# Altium schematic sheet Külmkapivalvur.SchDoc
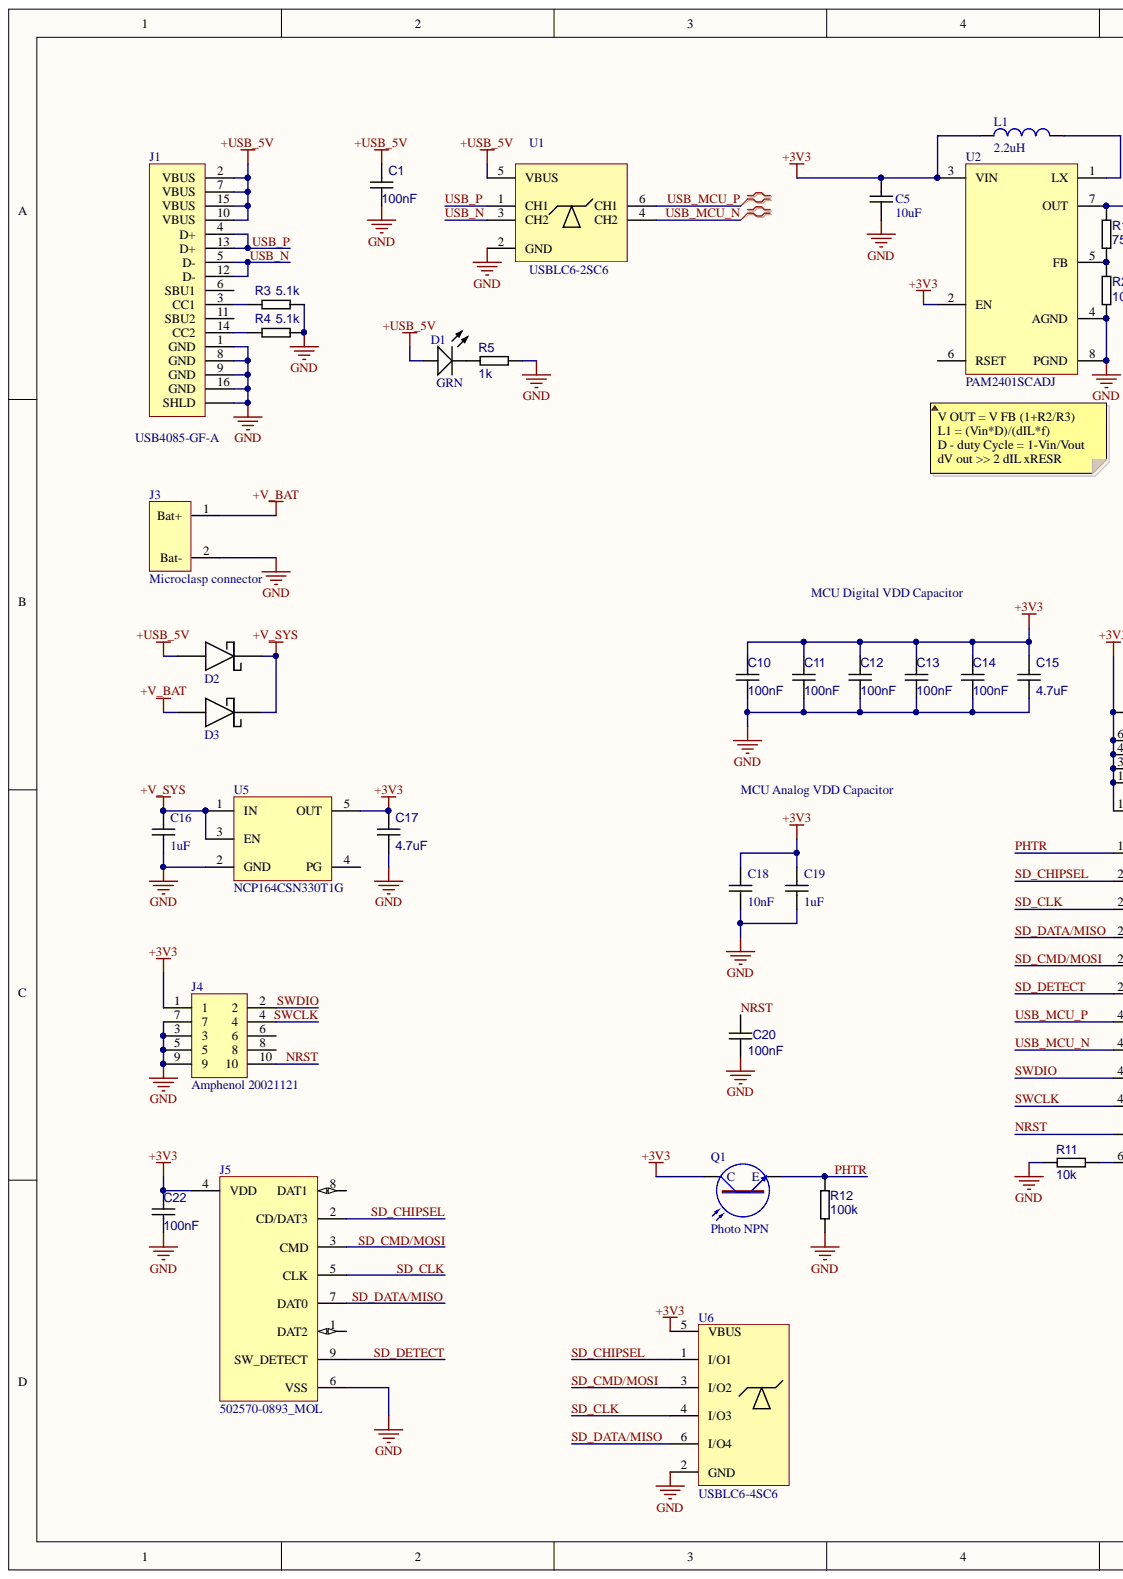
[diagram p0: Külmkapivalvur.SchDoc, left]
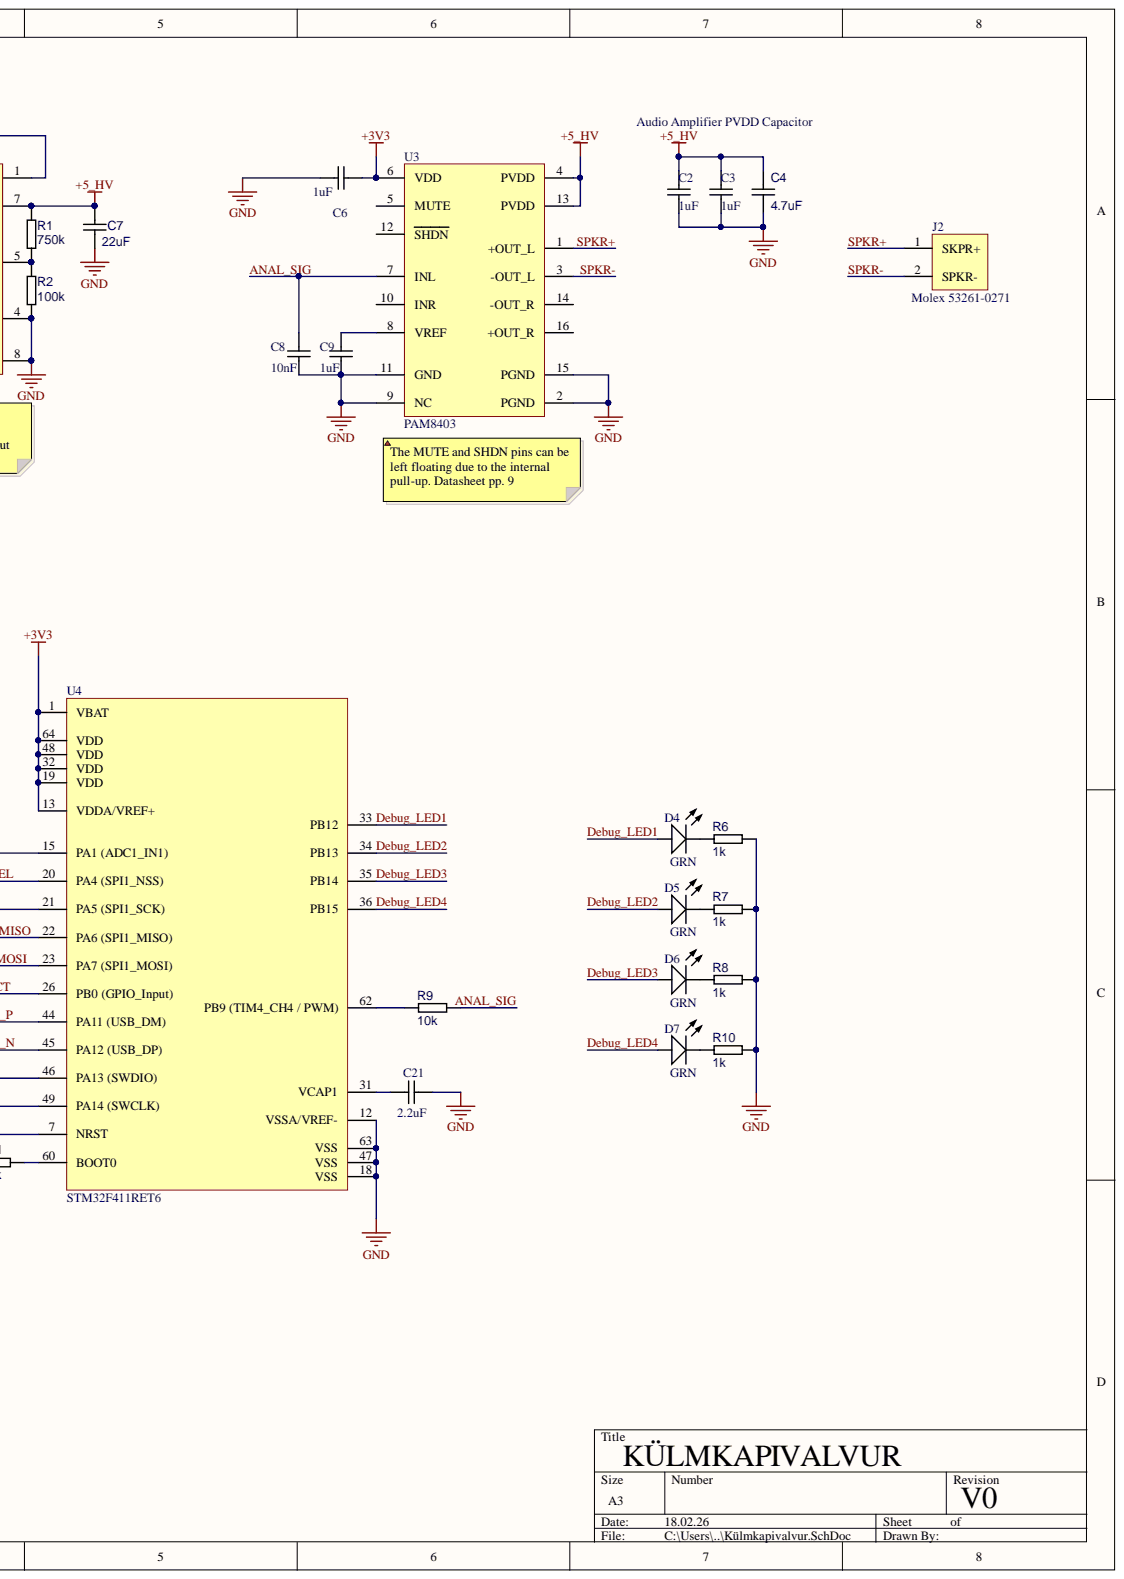
[diagram p1: Külmkapivalvur.SchDoc, right]

## Parts
C1  100nF  [0603C]
C2  1uF  [0603C]
C3  1uF  [0603C]
C4  4.7uF  [0603C]
C5  10uF  [0603C]
C6  1uF  [0603C]
C7  22uF  [0805C]
C8  10nF  [0603C]
C9  1uF  [0603C]
C10  100nF  [0603C]
C11  100nF  [0603C]
C12  100nF  [0603C]
C13  100nF  [0603C]
C14  100nF  [0603C]
C15  4.7uF  [0603C]
C16  1uF  [0603C]
C17  4.7uF  [0603C]
C18  10nF  [0603C]
C19  1uF  [0603C]
C20  100nF  [0603C]
C21  2.2uF  [0603C]
C22  100nF  [0603C]
D1  GRN  [LED_GRN]
D2  NRVBSS36FA  [NRVBSS36FA]  (value not drawn)
D3  NRVBSS36FA  [NRVBSS36FA]  (value not drawn)
D4  GRN  [LED_GRN]
D5  GRN  [LED_GRN]
D6  GRN  [LED_GRN]
D7  GRN  [LED_GRN]
J1  USB4085-GF-A  [CONN16_USB4085-GF-A_GCT]
J2  Molex 53261-0271  [Speaker connector]
J3  Microclasp connector  [CONN02_559350210_MOL]
J4  Amphenol 20021121  [AMPHENOL_20021121-00010C4LF]
J5  502570-0893_MOL  [502570-0893_MOL]
L1  value 2.2uH  [IND_NR4018_2.2uH]  (hidden comment: Inductor)
Q1  Photo NPN  [Phototransistor]
R1  750kR  value 750k  [0603R]
R2  100kR  value 100k  [0603R]
R3  value 5.1k  [0603R]  (hidden comment: 5.1kR)
R4  value 5.1k  [0603R]  (hidden comment: 5.1kR)
R5  value 1k  [0603R]  (hidden comment: 1kR)
R6  value 1k  [0603R]  (hidden comment: 1kR)
R7  value 1k  [0603R]  (hidden comment: 1kR)
R8  value 1k  [0603R]  (hidden comment: 1kR)
R9  10kR  value 10k  [0603R]
R10  value 1k  [0603R]  (hidden comment: 1kR)
R11  10kR  value 10k  [0603R]
R12  100kR  value 100k  [0603R]
U1  USBLC6-2SC6  [SOT23-6_ESD]
U2  PAM2401SCADJ  [MSOP-8_PAM2401]
U3  PAM8403  [SOIC16_PAM8403]
U4  STM32F411RET6  [STM32F411RET6]
U5  NCP164CSN330T1G  [SOT23-5_LDO]
U6  USBLC6-4SC6  [SOT23-6_ESD]

## Nets
+3V3: C5.2 C6.2 C10.2 C11.2 C12.2 C13.2 C14.2 C15.2 C17.2 C18.2 C19.2 C22.2 J4.1 J5.4(VDD) L1.1 Q1.1 U2.2(EN) U2.3(VIN) U3.6(VDD) U4.1(VBAT) U4.13(VDDA/VREF+) U4.19(VDD) U4.32(VDD) U4.48(VDD) U4.64(VDD) U5.5(OUT) U6.5(VBUS)
+5_HV: C2.2 C3.2 C4.2 C7.2 R1.2 U2.7(OUT) U3.4(PVDD) U3.13(PVDD)
+USB_5V: C1.2 D1.1 D2.2 J1.2(VBUS) J1.7(VBUS) J1.10(VBUS) J1.15(VBUS) U1.5(VBUS)
+V_BAT: D3.2 J3.1
+V_SYS: C16.2 D2.1 D3.1 U5.1(IN) U5.3(EN)
GND: C1.1 C2.1 C3.1 C4.1 C5.1 C6.1 C7.1 C8.1 C9.1 C10.1 C11.1 C12.1 C13.1 C14.1 C15.1 C16.1 C17.1 C18.1 C19.1 C20.1 C21.2 C22.1 J1.1(GND) J1.8(GND) J1.9(GND) J1.16(GND) J1.SHLD J3.2 J4.3 J4.5 J4.7 J4.9 J5.6(VSS) R2.1 R3.1 R4.1 R5.2 R6.2 R7.2 R8.2 R10.2 R11.1 R12.1 U1.2(GND) U2.4(AGND) U2.8(PGND) U3.2(PGND) U3.9(NC) U3.11(GND) U3.15(PGND) U4.12(VSSA/VREF-) U4.18(VSS) U4.47(VSS) U4.63(VSS) U5.2(GND) U6.2(GND)
ANAL_SIG: C8.2 R9.2 U3.7(INL)
Debug_LED1: D4.1 U4.33(PB12)
Debug_LED2: D5.1 U4.34(PB13)
Debug_LED3: D6.1 U4.35(PB14)
Debug_LED4: D7.1 U4.36(PB15)
NRST: C20.2 J4.10 U4.7(NRST)
PHTR: Q1.2 R12.2 U4.15(PA1_(ADC1_IN1))
SD_CHIPSEL: J5.2(CD/DAT3) U4.20(PA4_(SPI1_NSS)) U6.1(I/O1)
SD_CLK: J5.5(CLK) U4.21(PA5_(SPI1_SCK)) U6.4(I/O3)
SD_CMD/MOSI: J5.3(CMD) U4.23(PA7_(SPI1_MOSI)) U6.3(I/O2)
SD_DATA/MISO: J5.7(DAT0) U4.22(PA6_(SPI1_MISO)) U6.6(I/O4)
SD_DETECT: J5.9(SW_DETECT) U4.26(PB0_(GPIO_Input))
SPKR+: J2.1 U3.1(+OUT_L)
SPKR-: J2.2 U3.3(-OUT_L)
SWCLK: J4.4 U4.49(PA14_(SWCLK))
SWDIO: J4.2 U4.46(PA13_(SWDIO))
USB_MCU_N: U1.4(CH2) U4.45(PA12_(USB_DP))
USB_MCU_P: U1.6(CH1) U4.44(PA11_(USB_DM))
USB_N: J1.5(D-) J1.12(D-) U1.3(CH2)
USB_P: J1.4(D+) J1.13(D+) U1.1(CH1)
NetC9_2: C9.2 U3.8(VREF)
NetC21_1: C21.1 U4.31(VCAP1)
NetD1_2: D1.2 R5.1
NetD4_2: D4.2 R6.1
NetD5_2: D5.2 R7.1
NetD6_2: D6.2 R8.1
NetD7_2: D7.2 R10.1
NetJ1_3: J1.3(CC1) R3.2
NetJ1_14: J1.14(CC2) R4.2
NetL1_2: L1.2 U2.1(LX)
NetR1_1: R1.1 R2.2 U2.5(FB)
NetR9_1: R9.1 U4.62(PB9_(TIM4_CH4_/_PWM))
NetR11_2: R11.2 U4.60(BOOT0)

Not connected: J1 6(SBU1) 11(SBU2); J4 6 8; J5 1(DAT2) 8(DAT1); U2 6(RSET); U3 5(MUTE) 10(INR) 12(SHDN) 14(-OUT_R) 16(+OUT_R); U5 4(PG)

## Library data of the parts
C1 C10-C14 C20 C22 = 100nF: C 0603; Tolerance: 20%; Voltage Rating: 10V; Case/Package: 0402; Component Kind: Standard; ComponentLink1Description: Würth; ComponentLink1URL: https://www.we-online.com/en; Max Operating Temperature: 85°C; Min Operating Temperature: -55°C; Mounting Technology: SMT; Pins: 2; Library Name: RVP_Projekt.SCHLIB
C2 C3 C6 C9 C16 C19 = 1uF: C 0603; Component Kind: Standard; ComponentLink1Description: C0603C105K9RACTU; ComponentLink1URL: https://content.kemet.com/datasheets/KEM_C1002_X7R_SMD.pdf; Library Name: RVP_Projekt.SCHLIB
C4 C15 C17 = 4.7uF: C 0603; Tolerance: 20%; Voltage Rating: 10V; Case/Package: 0402; Component Kind: Standard; ComponentLink1Description: C0603C475K9PACTU; ComponentLink1URL: https://content.kemet.com/datasheets/KEM_C1006_X5R_SMD.pdf; Max Operating Temperature: 85°C; Min Operating Temperature: -55°C; Mounting Technology: SMT; Pins: 2; Library Name: RVP_Projekt.SCHLIB
C5 = 10uF: C 0603; Component Kind: Standard; ComponentLink1Description: C0603C103K8GACTU; ComponentLink1URL: https://content.kemet.com/datasheets/KEM_C1003_C0G_SMD.pdf; Library Name: RVP_Projekt.SCHLIB
C7 = 22uF: C 0805; Tolerance: 20%; Voltage Rating: 10V; Case/Package: 0402; Component Kind: Standard; Max Operating Temperature: 85°C; Min Operating Temperature: -55°C; Mounting Technology: SMT; Pins: 2; Library Name: RVP_Projekt.SCHLIB
C8 C18 = 10nF: C 0603; Component Kind: Standard; ComponentLink1Description: C0603C103K8GACTU; ComponentLink1URL: https://content.kemet.com/datasheets/KEM_C1003_C0G_SMD.pdf; Library Name: RVP_Projekt.SCHLIB
C21 = 2.2uF: C 0603; Component Kind: Standard; Library Name: RVP_Projekt.SCHLIB
D1 D4-D7 = LED: LED Uni-Color Green, 52 mW, 20 mA; Manufacturer: Avago; Forward Current: 20mA; Forward Voltage: 2.2V; Lens Style: Diffused; Luminous Intensity: 15mcd; Power: 52mW; Viewing Angle: 170degrees; Case/Package: 0603; Colour: Green; Component Kind: Standard; ComponentLink1Description: 150080VS75000; ComponentLink1URL: https://ee.farnell.com/wurth-elektronik/150080vs75000/led-0805-b-green-40mcd-570nm/dp/2322081; Datasheet URL: http://docs.avagotech.com/docs/AV02-0551EN; Datasheet Version: Mar-12; Illumination Colour: Green; Manufacturer URL: http://www.avagotech.com/; Max Operating Temperature: 85°C; Min Operating Temperature: -40°C; Mounting Technology: SM; Nominal Vf: 2.2V; Package Description: 2-Pin Surface Mount Device, Body 1.6 x 0.8 mm, Height 0.9 mm; Package Quantity: 4000; Package Reference: HSMX-C190; Packaging: TapeandReel; Pins: 2; RoHS Compliant: True; Library Name: RVP_Projekt.SCHLIB
J1 = USB4085-GF-A: USB Connector, 16 Contact(s), Female, Right Angle, Solder Terminal, Locking, Receptacle; Manufacturer: Global Connector Technology; Contact Resistance: 50mΩ; Current Rating: 250mA; Dielectric Material: Liquid Crystal Polymer; Insulation Resistance: 100MΩ; Number of Contacts: 16; Number of Ports: 1; Operating Force: 20N; Row Spacing: 1.35mm; USB Standard: USB 2.0; Voltage Rating: 20V; China RoHS: Compliant; Component Kind: Standard; ComponentLink1Description: https://datasheet.octopart.com:443/USB4085-GF-A-GCT-datasheet-188869075.pdf?src-supplier=TME; ComponentLink1URL: https://datasheet.octopart.com:443/USB4085-GF-A-GCT-datasheet-188869075.pdf?src-supplier=TME; ComponentLink2Description: https://datasheet.octopart.com:443/USB4085-GF-A-GCT-datasheet-166929322.pdf?src-supplier=TME; ComponentLink2URL: https://datasheet.octopart.com:443/USB4085-GF-A-GCT-datasheet-166929322.pdf?src-supplier=TME; ComponentLink3Description: https://datasheet.octopart.com:443/USB4085-GF-A-Global-Connector-Technology-datasheet-155825551.pdf?src-supplier=Future+Electronics; ComponentLink3URL: https://datasheet.octopart.com:443/USB4085-GF-A-Global-Connector-Technology-datasheet-155825551.pdf?src-supplier=Future+Electronics; ComponentLink4Description: https://datasheet.octopart.com:443/USB4085-GF-A-Global-Connector-Technology-datasheet-115130528.pdf?src-supplier=Future+Electronics; ComponentLink4URL: https://datasheet.octopart.com:443/USB4085-GF-A-Global-Connector-Technology-datasheet-115130528.pdf?src-supplier=Future+Electronics; Contact Gender: Female; Contact Material: Gold, Nickel; Gender: Receptacle; Height: 3.66mm; Lifecycle Status: Production; Manufacturer Part Number: USB4085-GF-A; Max Operating Temperature: 85°C; Min Operating Temperature: -40°C; Number of Pins: 16; Number of Rows: 2; REACH SVHC: Yes; RoHS: Compliant; Shell Finish: Nickel; Shell Material: Stainless Steel; Terminal Pitch: 850µm; Library Name: RVP_Projekt.SCHLIB
J2 = SPKR: Component Kind: Standard; Library Name: RVP_Projekt.SCHLIB
J3 = Microclasp connector: https://www.molex.com/en-us/products/part-detail/559350210?display=pdf; Component Kind: Standard; Library Name: RVP_Projekt.SCHLIB
J4 = Amphenol 20021121: Manufacturer: Amphenol Communications Solutions; Manufacturer Part Number: 20021121-00010C4LF; Connector Type: Header, Male Pin; Contact Resistance: 30mΩ; Current Rating: 1A; Dielectric Material: Polyethylene; Gender: Male; Insulation Resistance: 100MΩ; Mating Post Length: 3.05mm; Max Voltage Rating (AC): 125V; Number of Contacts: 10; Operating Force: 2.1406N; Pitch: 1.27mm; Plating Thickness: 254nm; Row Spacing: 3.9mm; Stack Height: 3mm; China RoHS: Compliant; Color: Black; Component Kind: Standard; ComponentLink1Description: https://datasheet.octopart.com:443/20021121-00010C4LF-Amphenol-Communications-Solutions-datasheet-102830508.pdf?src-supplier=Electro+Sonic; ComponentLink1URL: https://datasheet.octopart.com:443/20021121-00010C4LF-Amphenol-Communications-Solutions-datasheet-102830508.pdf?src-supplier=Electro+Sonic; ComponentLink2Description: https://datasheet.octopart.com:443/20021121-00010C4LF-Amphenol-Communications-Solutions-datasheet-21379658.pdf?src-supplier=Farnell; ComponentLink2URL: https://datasheet.octopart.com:443/20021121-00010C4LF-Amphenol-Communications-Solutions-datasheet-21379658.pdf?src-supplier=Farnell; ComponentLink3Description: https://datasheet.octopart.com:443/20021121-00010C4LF-Amphenol-Communications-Solutions-datasheet-62305862.pdf?src-supplier=Farnell; ComponentLink3URL: https://datasheet.octopart.com:443/20021121-00010C4LF-Amphenol-Communications-Solutions-datasheet-62305862.pdf?src-supplier=Farnell; ComponentLink4Description: https://datasheet.octopart.com:443/20021121-00010C4LF-Amphenol-Communications-Solutions-datasheet-98396828.pdf?src-supplier=Farnell; ComponentLink4URL: https://datasheet.octopart.com:443/20021121-00010C4LF-Amphenol-Communications-Solutions-datasheet-98396828.pdf?src-supplier=Farnell; ComponentLink5Description: https://datasheet.octopart.com:443/20021121-00010C4LF-FCI-datasheet-17852665.pdf?src-supplier=Farnell; ComponentLink5URL: https://datasheet.octopart.com:443/20021121-00010C4LF-FCI-datasheet-17852665.pdf?src-supplier=Farnell; ComponentLink6Description: https://datasheet.octopart.com:443/20021121-00010C4LF-FCI-datasheet-8699946.pdf?src-supplier=element14+APAC; ComponentLink6URL: https://datasheet.octopart.com:443/20021121-00010C4LF-FCI-datasheet-8699946.pdf?src-supplier=element14+APAC; ComponentLink7Description: https://datasheet.octopart.com:443/20021121-00010C4LF-FCI-datasheet-8640585.pdf?src-supplier=element14+APAC; ComponentLink7URL: https://datasheet.octopart.com:443/20021121-00010C4LF-FCI-datasheet-8640585.pdf?src-supplier=element14+APAC; ComponentLink8Description: https://datasheet.octopart.com:443/20021121-00010C4LF-Amphenol-datasheet-170317324.pdf?src-supplier=Amar+Radio+Corporation; ComponentLink8URL: https://datasheet.octopart.com:443/20021121-00010C4LF-Amphenol-datasheet-170317324.pdf?src-supplier=Amar+Radio+Corporation; ComponentLink9Description: https://datasheet.octopart.com:443/20021121-00010C4LF-Amphenol-Communications-Solutions-datasheet-13395655.pdf?src-supplier=RS+(Formerly+Allied+Electronics); ComponentLink9URL: https://datasheet.octopart.com:443/20021121-00010C4LF-Amphenol-Communications-Solutions-datasheet-13395655.pdf?src-supplier=RS+(Formerly+Allied+Electronics); ComponentLink10Description: https://datasheet.octopart.com:443/20021121-00010C4LF-FCI-datasheet-13726100.pdf?src-supplier=_legacy+Avnet; ComponentLink10URL: https://datasheet.octopart.com:443/20021121-00010C4LF-FCI-datasheet-13726100.pdf?src-supplier=_legacy+Avnet; Contact Gender: Male; Contact Material: Gold, Nickel; Contact Mating Length: 3.048mm; Contact Plating: Gold; Depth: 3.4mm; Height: 4.8mm; Housing Color: Black; Housing Material: Thermoplastic; Length: 6.35mm; Lifecycle Status: Production; Max Operating Temperature: 105°C; Min Operating Temperature: -40°C; Mount: Surface Mount; Number of Pins: 10; Number of Positions: 10; Number of Rows: 2; Pricing 1: 1200=0.434, 3600=0.428 (GBP); Radiation Hardening: No; REACH SVHC: Yes; RoHS: Compliant; Stock 1: 0; Supplier 1: Farnell; Supplier Part Number 1: 4731771; Terminal Pitch: 1.27mm; Termination: Solder; Voltage Rating: 125V; Library Name: RVP_Projekt.SCHLIB
J5 = Molex SD-card_holder: 1040310811; Connector Type: Connector; Contact Resistance: 100mR; Current Rating: 500mA; Gender: Receptacle; Insulation Resistance: 1GR; Mating Cycles: 10000; Max Voltage Rating (AC): 125V; Number of Contacts: 8; Pitch: 1.1mm; Color: Black; Component Kind: Standard; ComponentLink1Description: 1040310811; ComponentLink1URL: https://www.molex.com/en-us/products/part-detail/1040310811; Contact Material: Copper Alloy; Contact Plating: Gold; Depth: 15.95mm; Flammability Rating: UL94 V-0; Housing Material: Polymer; Lead Free: Lead Free; Length: 13.85mm; Max Current Rating: 500mA; Max Operating Temperature: 85°C; Min Operating Temperature: -25°C; Mount: Surface Mount; Number of Rows: 2; Orientation: Right Angle; Package Quantity: 400; Packaging: Tape and Reel; Radiation Hardening: No; REACH SVHC: No SVHC; Series: DM3; Termination: Solder; Voltage Rating: 125V; Voltage Rating (AC): 125V; Width: 13.85mm; Library Name: RVP_Projekt.SCHLIB
L1 = Inductor 2.2H: Shielded Power Inductors – EPL2010; SimulationGenericComponent: True; Component Kind: Standard; Library Name: RVP_Projekt.SCHLIB
Q1 = Photo NPN: 1541201NEA400; Component Kind: Standard; Library Name: RVP_Projekt.SCHLIB
R1 = 750kR: R 0603; Power: 63mW; Tolerance: 1%; Case/Package: 0402; Component Kind: Standard; Mounting Technology: SMT; Pins: 2; Library Name: RVP_Projekt.SCHLIB
R2 R12 = 100kR: R 0603; Power: 63mW; Tolerance: 1%; Case/Package: 0402; Component Kind: Standard; Mounting Technology: SMT; Pins: 2; Library Name: RVP_Projekt.SCHLIB
R3 R4 = 5.1kR: R 0603; Power: 63mW; Tolerance: 1%; Case/Package: 0402; Component Kind: Standard; Mounting Technology: SMT; Pins: 2; Library Name: RVP_Projekt.SCHLIB
R5-R8 R10 = 1kR: R 0603; Power: 63mW; Tolerance: 1%; Case/Package: 0402; Component Kind: Standard; Mounting Technology: SMT; Pins: 2; Library Name: RVP_Projekt.SCHLIB
R9 R11 = 10kR: R 0603; Power: 63mW; Tolerance: 1%; Case/Package: 0402; Component Kind: Standard; Mounting Technology: SMT; Pins: 2; Library Name: RVP_Projekt.SCHLIB
U1 = USBLC6-2SC6: Trans Voltage Suppressor Diode, Unidirectional, 1 Element, Silicon, MO-178AA; Max Power Dissipation: 225mW; Component Kind: Standard; Pins: 5; RoHS Compliant: Yes; Library Name: RVP_Projekt.SCHLIB
U2 = PAM2401SCADJ: https://4donline.ihs.com/images/VipMasterIC/IC/DIOD/DIODS21539/DIODS21539-1.pdf?hkey=6D3A4C79FDBF58556ACFDE234799DDF0; Component Kind: Standard; Library Name: RVP_Projekt.SCHLIB
U3 = PAM8403: https://www.farnell.com/datasheets/4546975.pdf; Component Kind: Standard; Library Name: RVP_Projekt.SCHLIB
U4 = STM32F411RET6: High-performance access line, Arm Cortex-M4 core with DSP and FPU, 512 Kbytes of Flash memory, 100 MHz CPU, ART Accelerator; Manufacturer_Name: STMicroelectronics; Manufacturer_Part_Number: STM32F411CEU7; Component Kind: Standard; Datasheet: https://www.st.com/resource/en/datasheet/stm32f411ce.pdf; RefDes: RefDes; Type: Type; Copyright: Copyright (C) 2025 Ultra Librarian. All rights reserved.; Library Name: RVP_Projekt.SCHLIB
D2 D3 = NRVBSS36FA: Component Kind: Standard; Library Name: RVP_Projekt.SCHLIB
U5 = NCP164CSN330T1G: Component Kind: Standard; Library Name: RVP_Projekt.SCHLIB
U6 = USBLC6-4SC6: Component Kind: Standard; Library Name: RVP_Projekt.SCHLIB
